# Altium schematic sheet 5.MS5611.SchDoc(5.MS5611)
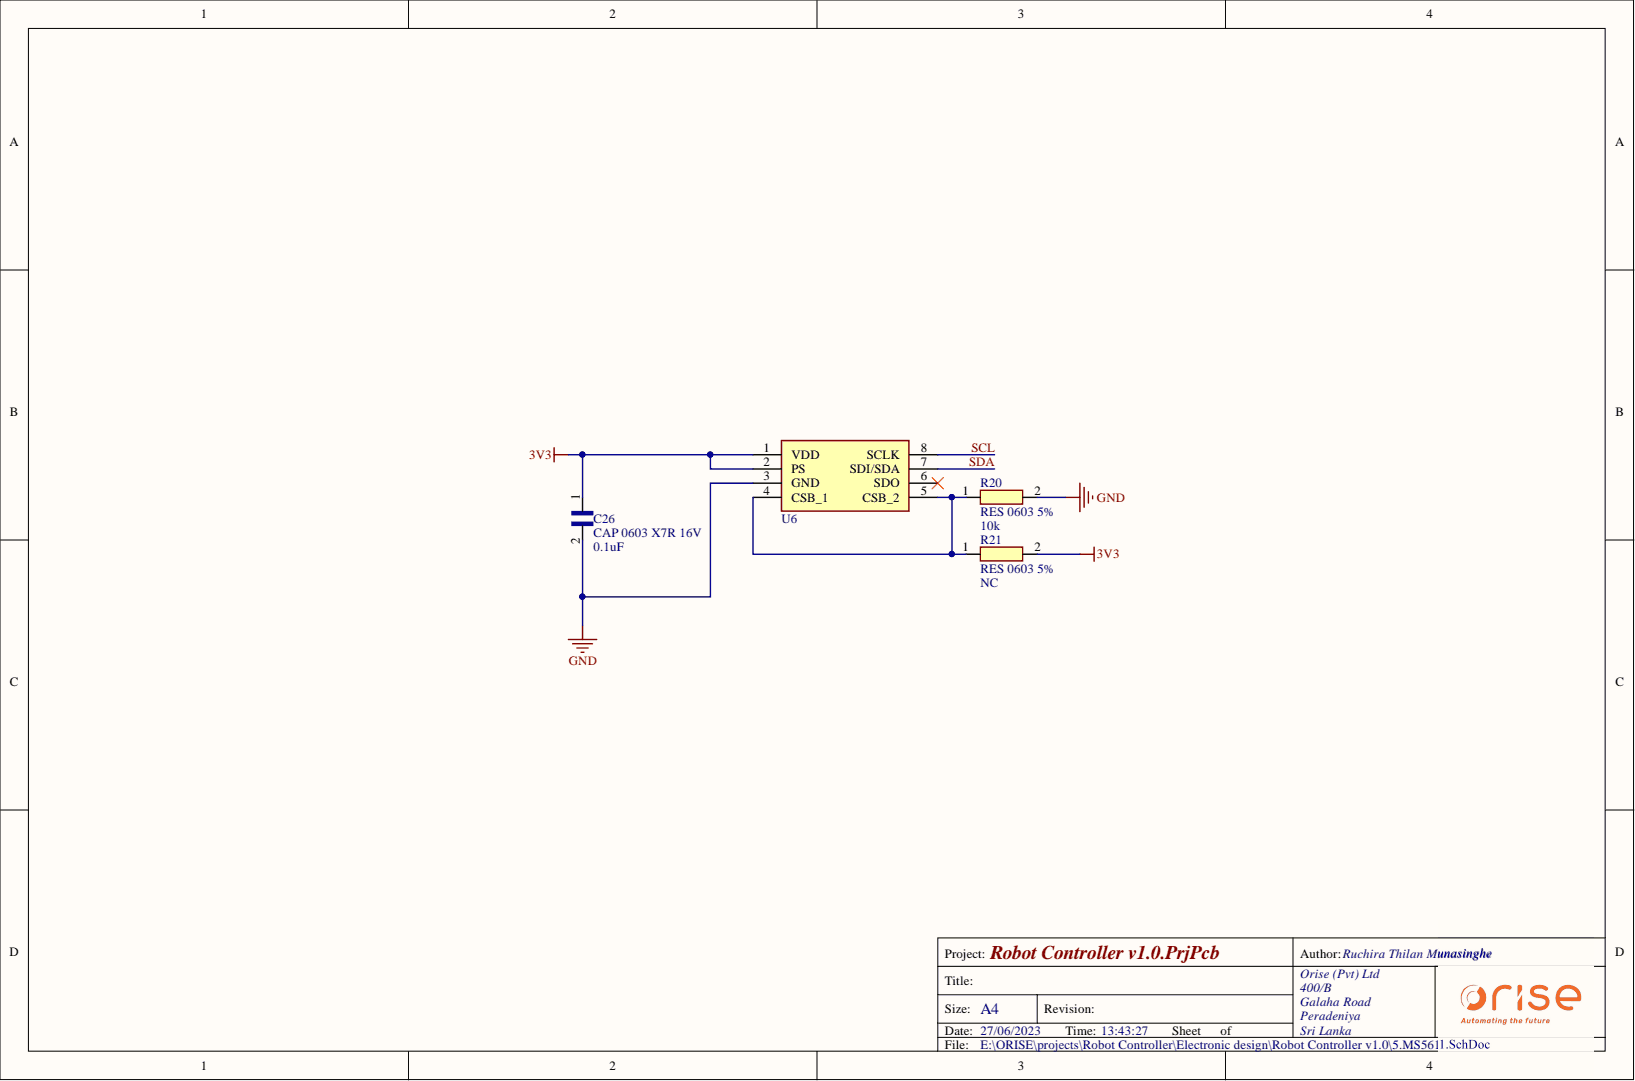
[diagram p0: 5.MS5611.SchDoc]

## Parts
C26  CAP 0603 X7R 16V  value 0.1uF  [CAP 0603]
R20  RES 0603 5%  value 10k  [RES 0603]
R21  RES 0603 5%  value NC  [RES 0603 NC]
U6  MS5611  [MS5611]  (value not drawn)

## Nets
3V3: C26.1 R21.2 U6.1(VDD) U6.2(PS)
GND: C26.2 R20.2 U6.3(GND)
SCL: U6.8(SCLK)
SDA: U6.7(SDI/SDA)
NetR20_1: R20.1 R21.1 U6.4(CSB_1) U6.5(CSB_2)

Not connected: U6 6(SDO)

## Library data of the parts
R20 = RES 0603 5%: value: 10k; Library Name: Robot Controller v1.0.SchLib; Description: RES 0603 5%; Component Kind: Standard
R21 = RES 0603 5% NC: Library Name: Robot Controller v1.0.SchLib; Description: RES 0603 5%; Component Kind: Standard
U6 = MS5611: Integrated Circuit; Library Name: Robot Controller v1.0.SchLib; Component Kind: Standard
C26 = CAP 0603 X7R 16V: Library Name: Robot Controller v1.0.SchLib; Description: CAP 0603 X7R 16V; Component Kind: Standard
